# Altium schematic sheet schematic3.SchDoc
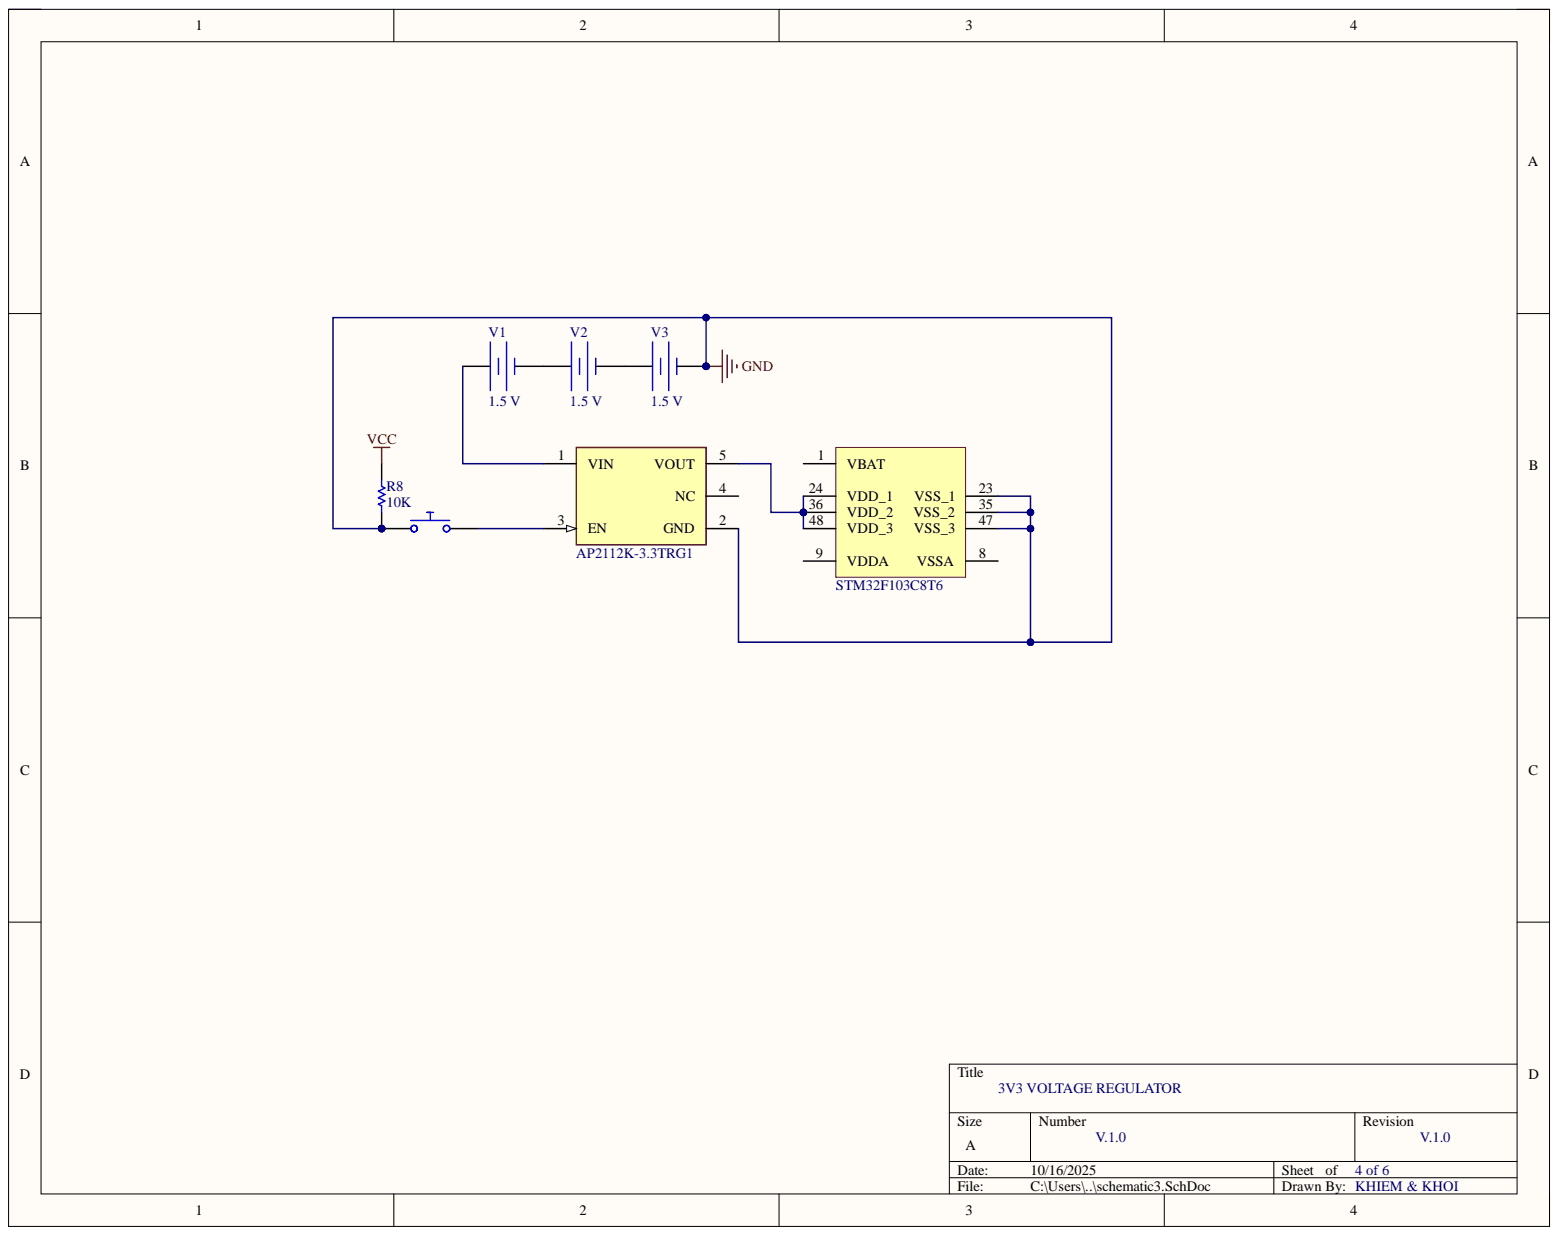
[diagram p0: schematic3.SchDoc]

## Parts
B  Push Button Normally Opened  (value not drawn)  (part )
B  AP2112K-3.3TRG1  [FP-SOT25-IPC_A]
R8  value 10K  (hidden comment: Resistor)
V1  DC Magnitude: 1.5 V  (hidden comment: Constant Voltage Source)
V2  DC Magnitude: 1.5 V  (hidden comment: Constant Voltage Source)
V3  DC Magnitude: 1.5 V  (hidden comment: Constant Voltage Source)

## Nets
GND: B.1 B.2 B.23(VSS_1) B.35(VSS_2) B.47(VSS_3) R8.1 V3.2
Net_1: B.1 V1.1
Net_2: B.2 B.3(EN)
Net_4: B.4(NC)
Net_5: B.5(VOUT) B.24(VDD_1) B.36(VDD_2) B.48(VDD_3)
Net_8: B.8(VSSA)
Net_9: B.9(VDDA)
VCC: R8.2
NetV1_2: V1.2 V2.1
NetV2_2: V2.2 V3.1

## Library data of the parts
B = Push Button NO: closed: 0; Rclosed: 1m; Ropen: 1Meg; SimulationGenericComponent: True; Component Kind: Standard; Description: Push Button Normally Opened; Library Name: Simulation Generic Components.IntLib; Simulation: PUSH_BUTTON_NO
B = CMP-12756-000035-1: IC REG LINEAR 3.3V 600MA SOT25; Accuracy: 1.5%; Dropout Voltage: 250mV; Max Input Voltage: 6V; Min Input Voltage: 2.5V; Number of Outputs: 1; Output Type: Fixed; Polarity: Positive; Quiescent Current: 55uA; Case/Package: SOT-23-5; Component Kind: Standard; Height: 1.3mm; Lead Free: Lead Free; Length: 3.1mm; Library Name: Altium Content Vault; Max Operating Temperature: 85°C; Max Output Current: 600mA; Min Operating Temperature: -40°C; Mount: Surface Mount; Number of Pins: 5; Number of Regulators: 1; Output Current: 600mA; Output Voltage: 3.3V; Package Quantity: 1; Packaging: Tape and Reel; Width: 1.7mm
R8 = Resistor: SimulationGenericComponent: True; TC1: 0; Component Kind: Standard; Description: Resistor; Library Name: Simulation Generic Components.IntLib; Simulation: R_Resistor_Default; TC2: 0
V1-V3 = Battery: SimulationGenericComponent: True; Component Kind: Standard; Description: Constant Voltage Source; Library Name: Simulation Generic Components.IntLib; Simulation: Vconst
